# Altium schematic sheet lv_mcu_ios_100.SchDoc(lv_mcu_ios_100)
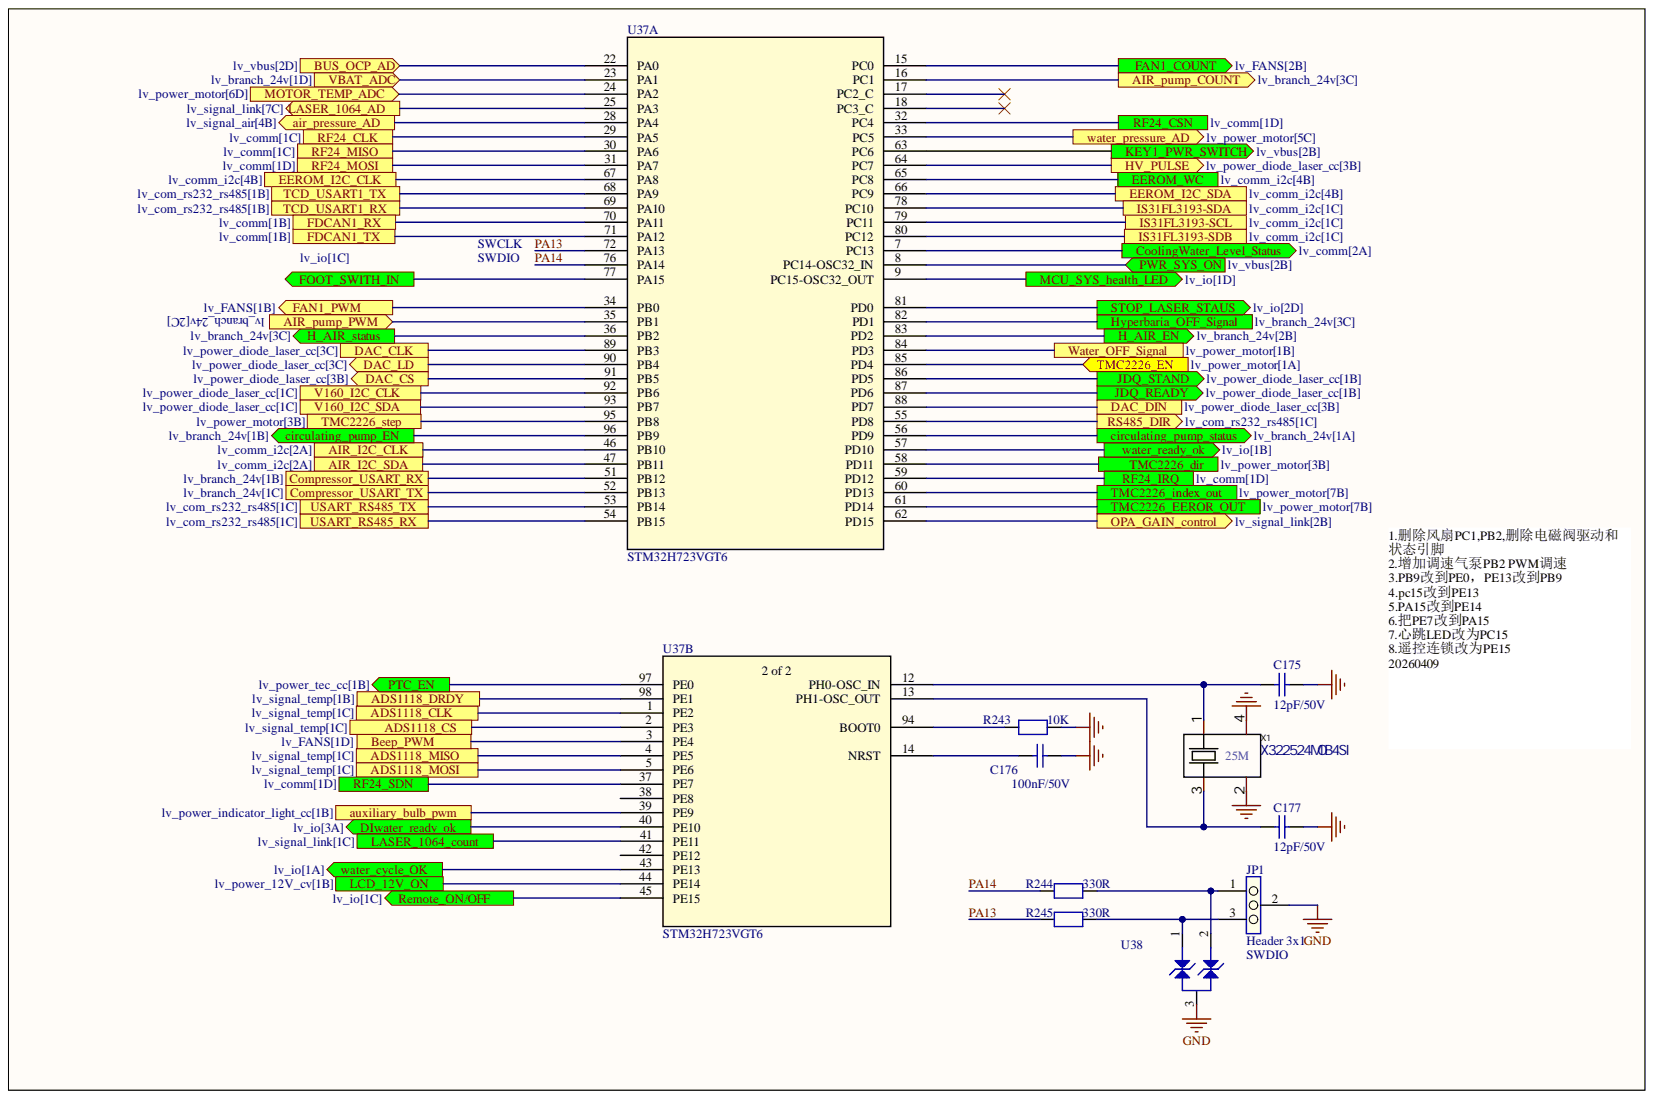
[diagram p0: lv_mcu_ios_100.SchDoc]

## Parts
C175  12pF/50V  [C0402]
C176  100nF/50V  [C0402]
C177  12pF/50V  [C0402]
JP1  value Header 3x1  [CON_SAMTEC_TSW-103-07-F-S]  (hidden comment: Jumper_Header 3x1)
R243  10K  [R0402]
R244  330R  [R0402]
R245  330R  [R0402]
U37  STM32H723VGT6  [LQFP100_STM-L]  (parts A,B)
U38  ESDCAN03-2BWY  [DIO_SOT323]  (value not drawn)
X1  X322524MOB4SI  [CRYSTAL-SMD_4P-L3.2-W2.5-BL]

## Ports
ADS1118_CLK, ADS1118_CS, ADS1118_DRDY, ADS1118_MISO, ADS1118_MOSI, AIR_I2C_CLK, AIR_I2C_SDA, air_pressure_AD, AIR_pump_COUNT, AIR_pump_PWM, auxiliary_bulb_pwm, Beep_PWM, BUS_OCP_AD, circulating_pump_EN, circulating_pump_status, Compressor_USART_RX, Compressor_USART_TX, CoolingWater_Level_Status, DAC_CLK, DAC_CS, DAC_DIN, DAC_LD, DIwater_ready_ok, EEROM_I2C_CLK, EEROM_I2C_SDA, EEROM_WC, FAN1_COUNT, FAN1_PWM, FDCAN1_RX, FDCAN1_TX, FOOT_SWITH_IN, H_AIR_EN, H_AIR_status, HV_PULSE, Hyperbaria_OFF_Signal, IS31FL3193-SCL, IS31FL3193-SDA, IS31FL3193-SDB, JDQ_READY, JDQ_STAND, KEY1_PWR_SWITCH, LASER_1064_AD, LASER_1064_count, LCD_12V_ON, MCU_SYS_health_LED, MOTOR_TEMP_ADC, OPA_GAIN_control, PTC_EN, PWR_SYS_ON, Remote_ON/OFF, RF24_CLK, RF24_CSN, RF24_IRQ, RF24_MISO, RF24_MOSI, RF24_SDN, RS485_DIR, STOP_LASER_STAUS, TCD_USART1_RX, TCD_USART1_TX, TMC2226_dir, TMC2226_EEROR_OUT, TMC2226_EN, TMC2226_index_out, TMC2226_step, USART_RS485_RX, USART_RS485_TX, V160_I2C_CLK, V160_I2C_SDA, VBAT_ADC, water_cycle_OK, Water_OFF_Signal, water_pressure_AD, water_ready_ok

## Nets
GND: C175.1 C176.1 C177.1 JP1.2 R243.2 U38.3 X1.2 X1.4
ADS1118_CLK: U37.1(PE2) ; port ADS1118_CLK
ADS1118_CS: U37.2(PE3) ; port ADS1118_CS
ADS1118_DRDY: U37.98(PE1) ; port ADS1118_DRDY
ADS1118_MISO: U37.4(PE5) ; port ADS1118_MISO
ADS1118_MOSI: U37.5(PE6) ; port ADS1118_MOSI
AIR_I2C_CLK: U37.46(PB10) ; port AIR_I2C_CLK
AIR_I2C_SDA: U37.47(PB11) ; port AIR_I2C_SDA
air_pressure_AD: U37.28(PA4) ; port air_pressure_AD
AIR_pump_COUNT: U37.16(PC1) ; port AIR_pump_COUNT
AIR_pump_PWM: U37.35(PB1) ; port AIR_pump_PWM
auxiliary_bulb_pwm: U37.39(PE9) ; port auxiliary_bulb_pwm
Beep_PWM: U37.3(PE4) ; port Beep_PWM
BUS_OCP_AD: U37.22(PA0) ; port BUS_OCP_AD
circulating_pump_EN: U37.96(PB9) ; port circulating_pump_EN
circulating_pump_status: U37.56(PD9) ; port circulating_pump_status
Compressor_USART_RX: U37.51(PB12) ; port Compressor_USART_RX
Compressor_USART_TX: U37.52(PB13) ; port Compressor_USART_TX
CoolingWater_Level_Status: U37.7(PC13) ; port CoolingWater_Level_Status
DAC_CLK: U37.89(PB3) ; port DAC_CLK
DAC_CS: U37.91(PB5) ; port DAC_CS
DAC_DIN: U37.88(PD7) ; port DAC_DIN
DAC_LD: U37.90(PB4) ; port DAC_LD
DIwater_ready_ok: U37.40(PE10) ; port DIwater_ready_ok
EEROM_I2C_CLK: U37.67(PA8) ; port EEROM_I2C_CLK
EEROM_I2C_SDA: U37.66(PC9) ; port EEROM_I2C_SDA
EEROM_WC: U37.65(PC8) ; port EEROM_WC
FAN1_COUNT: U37.15(PC0) ; port FAN1_COUNT
FAN1_PWM: U37.34(PB0) ; port FAN1_PWM
FDCAN1_RX: U37.70(PA11) ; port FDCAN1_RX
FDCAN1_TX: U37.71(PA12) ; port FDCAN1_TX
FOOT_SWITH_IN: U37.77(PA15) ; port FOOT_SWITH_IN
H_AIR_EN: U37.83(PD2) ; port H_AIR_EN
H_AIR_status: U37.36(PB2) ; port H_AIR_status
HV_PULSE: U37.64(PC7) ; port HV_PULSE
Hyperbaria_OFF_Signal: U37.82(PD1) ; port Hyperbaria_OFF_Signal
IS31FL3193-SCL: U37.79(PC11) ; port IS31FL3193-SCL
IS31FL3193-SDA: U37.78(PC10) ; port IS31FL3193-SDA
IS31FL3193-SDB: U37.80(PC12) ; port IS31FL3193-SDB
JDQ_READY: U37.87(PD6) ; port JDQ_READY
JDQ_STAND: U37.86(PD5) ; port JDQ_STAND
KEY1_PWR_SWITCH: U37.63(PC6) ; port KEY1_PWR_SWITCH
LASER_1064_AD: U37.25(PA3) ; port LASER_1064_AD
LASER_1064_count: U37.41(PE11) ; port LASER_1064_count
LCD_12V_ON: U37.44(PE14) ; port LCD_12V_ON
MCU_SYS_health_LED: U37.9(PC15-OSC32_OUT) ; port MCU_SYS_health_LED
MOTOR_TEMP_ADC: U37.24(PA2) ; port MOTOR_TEMP_ADC
OPA_GAIN_control: U37.62(PD15) ; port OPA_GAIN_control
PA13: R245.1 U37.72(PA13)
PA14: R244.1 U37.76(PA14)
PTC_EN: U37.97(PE0) ; port PTC_EN
PWR_SYS_ON: U37.8(PC14-OSC32_IN) ; port PWR_SYS_ON
Remote_ON/OFF: U37.45(PE15) ; port Remote_ON/OFF
RF24_CLK: U37.29(PA5) ; port RF24_CLK
RF24_CSN: U37.32(PC4) ; port RF24_CSN
RF24_IRQ: U37.59(PD12) ; port RF24_IRQ
RF24_MISO: U37.30(PA6) ; port RF24_MISO
RF24_MOSI: U37.31(PA7) ; port RF24_MOSI
RF24_SDN: U37.37(PE7) ; port RF24_SDN
RS485_DIR: U37.55(PD8) ; port RS485_DIR
STOP_LASER_STAUS: U37.81(PD0) ; port STOP_LASER_STAUS
TCD_USART1_RX: U37.69(PA10) ; port TCD_USART1_RX
TCD_USART1_TX: U37.68(PA9) ; port TCD_USART1_TX
TMC2226_dir: U37.58(PD11) ; port TMC2226_dir
TMC2226_EEROR_OUT: U37.61(PD14) ; port TMC2226_EEROR_OUT
TMC2226_EN: U37.85(PD4) ; port TMC2226_EN
TMC2226_index_out: U37.60(PD13) ; port TMC2226_index_out
TMC2226_step: U37.95(PB8) ; port TMC2226_step
USART_RS485_RX: U37.54(PB15) ; port USART_RS485_RX
USART_RS485_TX: U37.53(PB14) ; port USART_RS485_TX
V160_I2C_CLK: U37.92(PB6) ; port V160_I2C_CLK
V160_I2C_SDA: U37.93(PB7) ; port V160_I2C_SDA
VBAT_ADC: U37.23(PA1) ; port VBAT_ADC
water_cycle_OK: U37.43(PE13) ; port water_cycle_OK
Water_OFF_Signal: U37.84(PD3) ; port Water_OFF_Signal
water_pressure_AD: U37.33(PC5) ; port water_pressure_AD
water_ready_ok: U37.57(PD10) ; port water_ready_ok
NetC175_2: C175.2 U37.12(PH0-OSC_IN) X1.1
NetC176_2: C176.2 U37.14(NRST)
NetC177_2: C177.2 U37.13(PH1-OSC_OUT) X1.3
NetJP1_1: JP1.1 R244.2 U38.2
NetJP1_3: JP1.3 R245.2 U38.1
NetR243_1: R243.1 U37.94(BOOT0)

Not connected: U37 17(PC2_C) 18(PC3_C) 38(PE8) 42(PE12)

## Library data of the parts
C175 C177 = MCD-00674: 50V,5%,C0G; Manufacturer: muRata; Manufacturer_Part_Number: GRM1555C1H120JA01D; Database Table Name: MCD_PARTS$; Component Kind: Standard; Library Name: MCD_PARTS_database.DbLib
  C177: ComponentLink1Description: Datasheet; ComponentLink1URL: http://tunmcdsvn.tun.st.com/Component_Datasheet_Library/Trunk/Capacitors/Yageo_Capacitor_NP0_16V-to-50V
C176 = MCD-00102: X7R, 10%; Manufacturer: YAGEO; Manufacturer_Part_Number: GRM155R71H104KE14D; Database Table Name: MCD_PARTS$; Component Kind: Standard; Library Name: MCD_PARTS_database.DbLib
JP1 = MCD-00111: Header, 3x1, 2,54mm, VR, TH, Height 5.84mm/2.54mm; Manufacturer: SAMTEC; Manufacturer_Part_Number: TSW-103-07-F-S; Part_Type: Connector; Initial_Board_Reference: MB1262; Item Type: Item; Path: ws/MCD_ApplicationBoards/Lists/MCDPARTSdatabase; Provided_By: SUBCO; Component Kind: Standard; ComponentLink1Description: Datasheet; ComponentLink1URL: http://tunmcdsvn.tun.st.com/Component_Datasheet_Library/Trunk/Connectors/Samtec_TSW-HTSW-series; Internal_Part_Number: MCD-00111; Library Name: MB1397.IntLib; Status: Released
R243 = RES: 1%; Component Kind: Standard; Library Name: HiPin_Library_AD.IntLib; Value: 10K
R244 R245 = RES: 1%; Value: 10K; Component Kind: Standard; Library Name: HiPin_Library_AD.IntLib
U37 = STM32H723VGT6: No Description Available; Mfr_Name: STMicroelectronics; Component Kind: Standard; Library Name: HiPin_Library_AD.IntLib; RefDes: RefDes; Type: Type
U38 = MCD-01228: 双线TVS,反向截止电压24V; Manufacturer: STMICROELECTRONICS; Database Table Name: MCD_PARTS$; Component Kind: Standard; Library Name: MCD_PARTS_database.DbLib; Manufacturer_Part_Number: ESDCAN03-2BWY
X1 = X322524MOB4SI: 24MHz 10ppm 12pF; Manufacturer: YXC(扬兴晶振); Add into BOM: yes; Crystal Type: 贴片无源晶振; Frequency Stability: ±30ppm; Component Kind: Standard; Convert to PCB: yes; Datasheet: https://atta.szlcsc.com/upload/public/pdf/source/20210329/C112971_1F611BDC486E193C1706F6D8EB5AA189.pdf; Device: X322524MOB4SI; Frequency Tolerance: ±10ppm; JLCPCB Part Class: Extended Part; LCSC Part Name: 24MHz ±10ppm 12pF YSX321SL; Library Name: HiPin_Library_AD.IntLib; Load Capacitance: 12pF; Manufacturer Part: X322524MOB4SI; Name: 24MHz; Operating Temperature: -40℃~+85℃; PCB3D: OSC-SMD_4P-L3.2-W2.5-H1.0; Supplier: LCSC; Supplier Footprint: SMD3225-4P; Supplier Part: C70590; Symbol: X322524MOB4SI; Value: 24MHz
